# Altium schematic sheet Sumotop_Control_WB_SCH.SchDoc
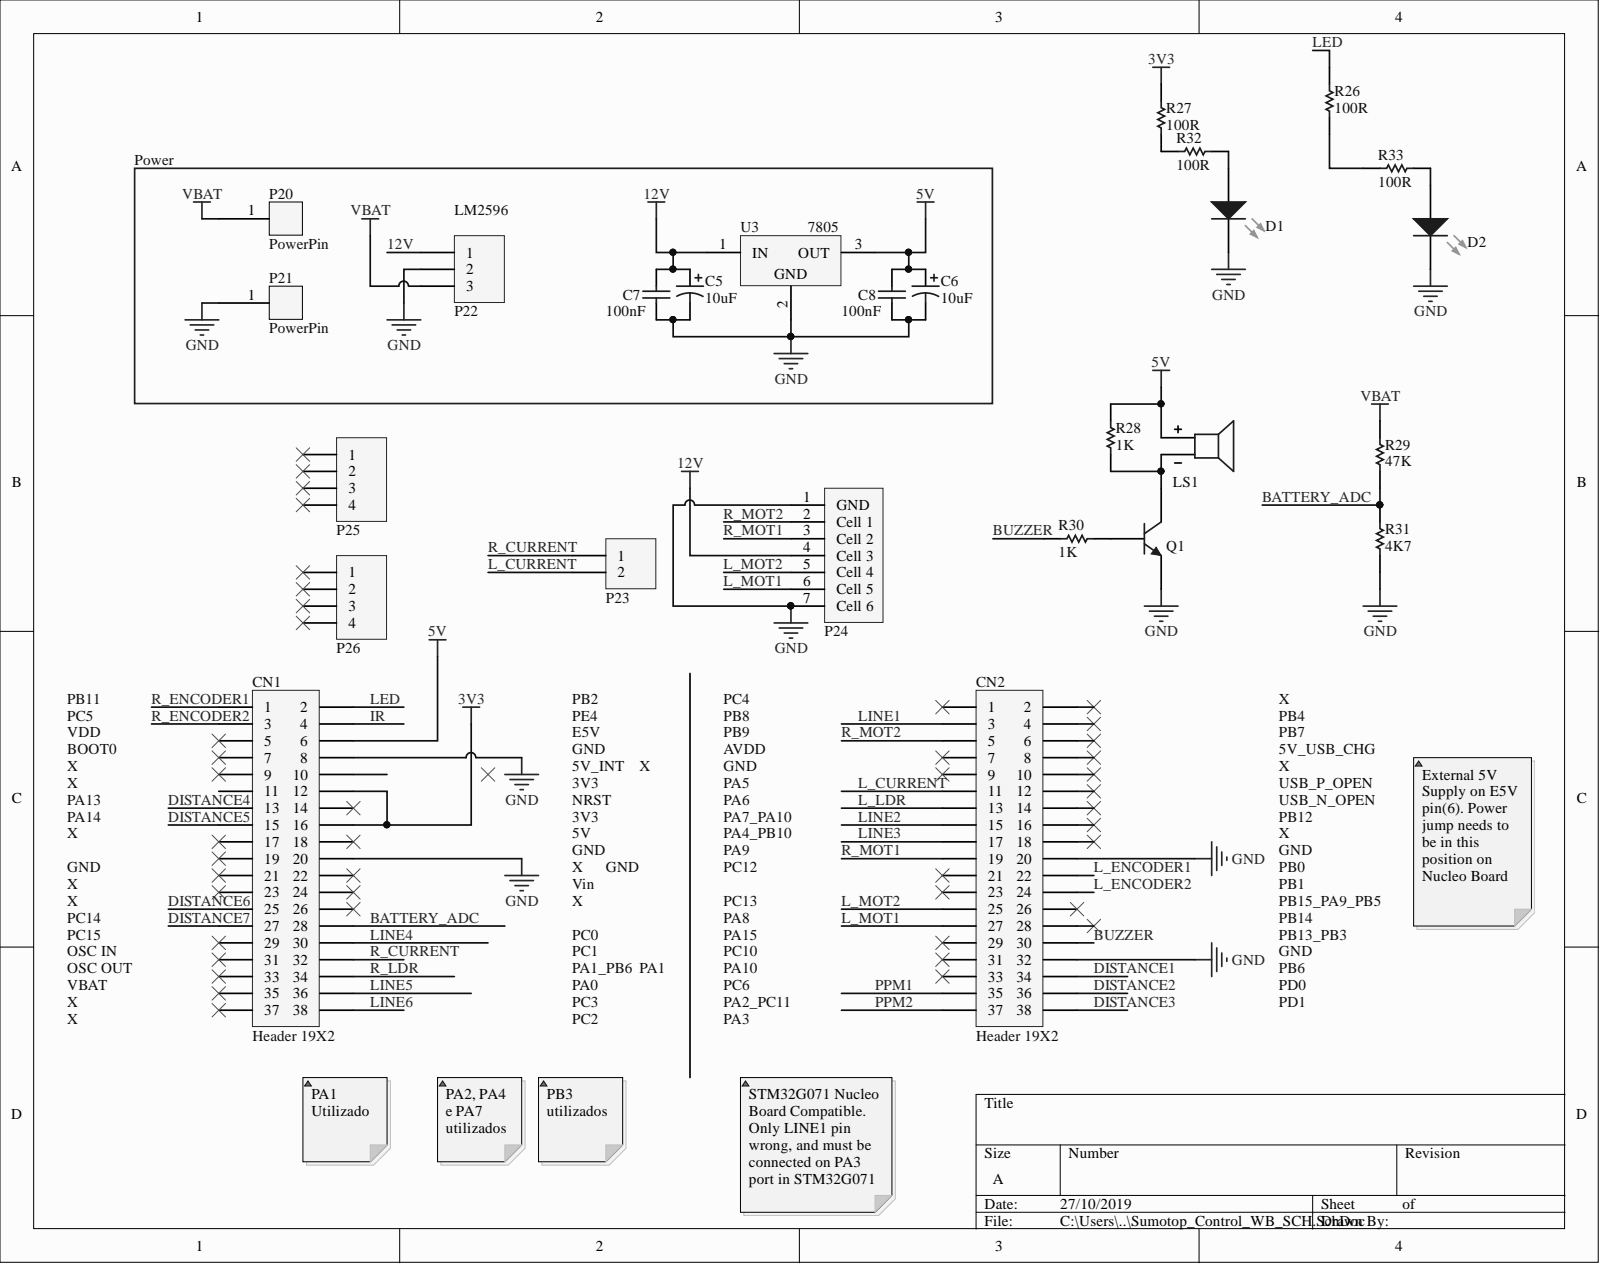
[diagram p0: Sumotop_Control_WB_SCH.SchDoc]

## Parts
C5  10uF  [CAP RAD 10UF 35V]
C6  10uF  [CAP RAD 10UF 35V]
C7  100nF  [Capacitor-0603]
C8  100nF  [Capacitor-0603]
CN1  Header 19X2  [HDR2x19]
CN2  Header 19X2  [HDR2x19]
D1  LED GREEN  [LED1411]  (value not drawn)
D2  LED WHITE  [LED1411]  (value not drawn)
LS1  Buzzer  [BUZZER 12x6MM]  (value not drawn)
P20  PowerPin  [PowerPad]
P21  PowerPin  [PowerPad]
P22  Header 1x3  [HDR1X3]  (value not drawn)
P23  Header 1x2  [HDR1X2]  (value not drawn)
P24  Header Male 1x7  [HDR MALE 1x7]  (value not drawn)
P25  Header 1x4  [HDR MALE 1x4]  (value not drawn)
P26  Header 1x4  [HDR MALE 1x4]  (value not drawn)
Q1  MMBT3904LT1G  [SOT23-3 M]  (value not drawn)
R26  100R  [RESISTOR 0603]
R27  100R  [RESISTOR 0603]
R28  1K  [RESISTOR 0603]
R29  47K  [RESISTOR 0603]
R30  1K  [RESISTOR 0603]
R31  4K7  [RESISTOR 0603]
R32  100R  [RESISTOR 0603]
R33  100R  [RESISTOR 0603]
U3  Name: 7805  [D-PAK]  (hidden comment: LM7805)

## Nets
3V3: CN1.12 CN1.16 R27.2
5V: C6.1 C8.2 CN1.6 LS1.1 R28.2 U3.3
GND: C5.2 C6.2 C7.1 C8.1 CN1.8 CN1.20 CN2.20 CN2.32 D1.2 D2.2 P21.1 P22.2 P24.1(GND) P24.7(Cell_6) Q1.2 R31.1 U3.2
VBAT: P20.1 P22.3 R29.2
12V: C5.1 C7.2 P22.1 P24.4(Cell_3) U3.1
BATTERY_ADC: CN1.28 R29.1 R31.2
BUZZER: CN2.30 R30.1
DISTANCE1: CN2.34
DISTANCE2: CN2.36
DISTANCE3: CN2.38
DISTANCE4: CN1.13
DISTANCE5: CN1.15
DISTANCE6: CN1.25
DISTANCE7: CN1.27
IR: CN1.4
L_CURRENT: CN2.11 P23.2
L_ENCODER1: CN2.22
L_ENCODER2: CN2.24
L_LDR: CN2.13
L_MOT1: CN2.27 P24.6(Cell_5)
L_MOT2: CN2.25 P24.5(Cell_4)
LED: CN1.2 R26.2
LINE1: CN2.3
LINE2: CN2.15
LINE3: CN2.17
LINE4: CN1.30
LINE5: CN1.36
LINE6: CN1.38
PPM1: CN2.35
PPM2: CN2.37
R_CURRENT: CN1.32 P23.1
R_ENCODER1: CN1.1
R_ENCODER2: CN1.3
R_LDR: CN1.34
R_MOT1: CN2.19 P24.3(Cell_2)
R_MOT2: CN2.5 P24.2(Cell_1)
NetD1_1: D1.1 R32.2
NetD2_1: D2.1 R33.2
NetLS1_2: LS1.2 Q1.3 R28.1
NetQ1_1: Q1.1 R30.2
NetR26_1: R26.1 R33.1
NetR27_1: R27.1 R32.1

Not connected: CN1 5 7 9 10 11 14 17 18 19 21 22 23 24 26 29 31 33 35 37; CN2 1 2 4 6 7 8 9 10 12 14 16 18 21 23 26 28 29 31 33; P25 1 2 3 4; P26 1 2 3 4

## Library data of the parts
C5 C6 = CAP 10uF ELE 16V: CAP ELE RAD 10uF 16V; Manufacturer Part Number: IMP1295; Capacitance Tolerance: ± 20%; Capacitor Terminals: Radial Leaded; Leakage Current: 6; Lifetime @ Temperature: 2000 hours @ 85; Product Range: MCGPR Series; SVHC: No SVHC (15-Jun-2015); Voltage Rating: 16; Capacitance: 10uF; Capacitance Tolerance ±: ± 20%; Component Kind: Standard; ComponentLink1Description: http://loja.multcomercial.com.br/capacitor-eletrolitico-10uf-16v.html; ComponentLink1URL: http://loja.multcomercial.com.br/capacitor-eletrolitico-10uf-16v.html; Diameter: 5; ESR: -; Height: 11; Lead Spacing: 2; Manufacturer: -; Operating Temperature Max: 85; Operating Temperature Min: -40; Operating Temperature Range: -40°C to +85°C<!--FANDB--><div class="features-and-benefits-container"><div class="features-and-benefits-description"><span class="features-benefits-value">The Multicomp MCGPR series capacitors are general purpose, radial leaded polarized aluminium electrolytic capacitors. MCGPR series parts cover broad range of voltage ratings and capacitance values.</span></div><div class="features-and-benefits-list"><ul class="features-and-benefits-list-list"><li class="features-and-benefits-list-item">Capacitance value ranges from 0.1µF to 22000µF for 6.3VDC to 100VDC</li><li class="features-and-benefits-list-item">Capacitance value ranges from 0.47µF to 820µF for 160VDC to 450VDC</li><li class="features-and-benefits-list-item">Tolerance of ±20%</li><li class="features-and-benefits-list-item">Endurance of 2000h at 85°C</li><li class="features-and-benefits-list-item">Available in -40°C to 85°C and -25°C to 85; Packaging: Each; Pricing 1: 1=0.50 (BRL); RoHS: YES; Stock 1: 33826; Supplier 1: Mult Comercial; Supplier Part Number 1: Capacitor Eletrolítico 10uF/16V; Library Name: Sumotop_Control.SchLib
C7 C8 = CAP 100NF 50V: Capacitor Cer disk 100nF 50V; Manufacturer Part Number: IMP14925; Capacitance: 0.1uF; Capacitance Tolerance: +80%, -20%; Capacitor Terminals: Radial Leaded; Dielectric Characteristic: Y5; Product Range: MCFY Series; SVHC: No SVHC (15-Jun-2015); Voltage Rating: 50; Capacitance Tolerance ±: +80%, -20%; Component Kind: Standard; ComponentLink1Description: http://loja.multcomercial.com.br/capacitor-ceramico-disco-100kpf-50v-104-100k-100nf-0-1uf-cod-1351.html; ComponentLink1URL: http://loja.multcomercial.com.br/capacitor-ceramico-disco-100kpf-50v-104-100k-100nf-0-1uf-cod-1351.html; Lead Spacing: 5; Manufacturer: -; Operating Temperature Max: 85; Operating Temperature Min: -25; Operating Temperature Range: -25°C to +85°C<!--FANDB--><div class="features-and-benefits-container"><div class="features-and-benefits-description"><span class="features-benefits-value">The MCFY series from Multicomp are radial leaded ceramic disc capacitors. The capacitance values ranges from 6800pF to 0.22?F.</span></div><div class="features-and-benefits-list"><ul class="features-and-benefits-list-list"><li class="features-and-benefits-list-item">Large capacitance in small size</li><li class="features-and-benefits-list-item">Rated voltage of 50V</li><li class="features-and-benefits-list-item">80%/-20% tolerance</li><li class="features-and-benefits-list-item">Operating temperature range -25°C to +85; Packaging: Each; Pricing 1: 1=0.10 (BRL); RoHS: YES; Stock 1: 115809; Supplier 1: Mult Comercial; Supplier Part Number 1: Capacitor Cerâmico Disco 100Kpf/50V (104/100K/100nF/0.1uF) cód. 1351; Library Name: Sumotop_Control_WB_SCHLIB.SchLib
CN1 CN2 = Header 19X2: Header, 19-Pin, Dual row; Component Kind: Standard; Revision: 17-Jul-2002: Re-released for DXP Platform.; Signal Integrity: Connector; Library Name: Sumotop_Control.SchLib; Publisher: Altium Limited
D1 = LED GREEN: Standard LEDs - SMD White TOPLED Black Surface; Manufacturer: Wurth Elektronik; Manufacturer Part Number: 150141GS73113; Luminous Intensity Typ: 2500; Component Kind: Standard; ComponentLink3Description: MOUSER2; ComponentLink3URL: https://br.mouser.com/ProductDetail/Wurth-Elektronik/150141GS73113?qs=sGAEpiMZZMseGfSY3csMkdgyOOAg6kv2zYAUfQmngkdzXM%252Bl%252BPVrNQ%3D%3D; Library Name: Sumotop_Control_WB_SCHLIB.SchLib
D2 = LED WHITE: Standard LEDs - SMD White TOPLED Black Surface; Manufacturer: OSRAM; Manufacturer Part Number: LW TWTG.BB-BYBZ; Luminous Intensity Typ: 2000; Component Kind: Standard; ComponentLink1Description: http://loja.multcomercial.com.br/led-3mm-vermelho-difuso-l-314hd-paralight.html; ComponentLink1URL: http://loja.multcomercial.com.br/led-3mm-vermelho-difuso-l-314hd-paralight.html; ComponentLink2Description: Mouser; ComponentLink2URL: https://br.mouser.com/ProductDetail/OSRAM-Opto-Semiconductors/LW-TWTGBB-BYBZ-2B12C3-Z486?qs=sGAEpiMZZMseGfSY3csMkdgyOOAg6kv20s3Ceu2Hg6%2Fq9qYEXiqoDQ%3D%3D; Library Name: Sumotop_Control_WB_SCHLIB.SchLib
LS1 = Buzzer Piezo transducter 30V th: Manufacturer: TDK Corporation; Manufacturer Part Number: PS1240P02BT; Driver Circuitry: Transducer, Externally Driven; Frequency: 4kHz; Input Type: Zero-Peak Signal; Operating Mode: Single Tone; Port Location: Top; Sound Pressure Level: 60dB @ 3V, 10cm; Voltage - Rated: 3V; Voltage Range: 30V (Max); Approvals: -; Category: Audio Products; Component Kind: Standard; ComponentLink1Description: https://product.tdk.com/info/en/catalog/datasheets/ef532_ps.pdf; ComponentLink1URL: https://product.tdk.com/info/en/catalog/datasheets/ef532_ps.pdf; Current - Supply: -; Description: Buzzer Piezo transducter 30V th; Duration: -; Height - Seated (Max): 0.307" (7.80mm); Mounting Type: Through Hole; Operating Temperature: -10°C ~ 70°C; Packaging: Cut Tape (CT); Part Status: Active; Pricing 1: 1=0.72, 10=0.622, 100=0.3889, 250=0.37776 (USD); Ratings: -; RoHS: RoHS Compliant; Size / Dimension: 0.480" Dia (12.20mm); Stock 1: 23730; Supplier 1: Digi-Key; Supplier Part Number 1: 445-2525-1-ND; Technology: Piezo; Termination: PC Pins; Library Name: Sumotop_Control.SchLib
P22 = Header 1x3: Headers & Wire Housings 40Pin 2mm Spacing Single Row Hdrs, 5pc; Manufacturer Part Number: IMP10327; Brand: Cromax; Mounting Angle: Vertical; Number of Positions: 40 Position; Accessory Type: -; Category: Headers & Wire Housings; Component Kind: Standard; ComponentLink1Description: http://loja.multcomercial.com.br/barra-de-pinos-simples-1x40-macho-bmo40-1e.html; ComponentLink1URL: http://loja.multcomercial.com.br/barra-de-pinos-simples-1x40-macho-bmo40-1e.html; Contact Gender: Pin (Male); Contact Plating: Gold; Contact Type: -; Manufacturer: Cromax; Minimum Order Quantity: 1; Mounting Style: Straight Pin; Number of Rows: 1 Row; Pitch: 2 mm; Pricing 1: 1=1.00, 5=0.20 (BRL); Product: Headers; Product Category: Accessories; Reeling: False; RoHS: RoHS Compliant; RoHS - Mouser: Y; Row Spacing: -; Stock 1: 14; Supplier 1: Mult Comercial; Supplier Part Number 1: Barra de Pinos Simples 1x40 Macho BMO40-1E; Termination Style: Through Hole; Type: Breakaway; Library Name: Sumotop_Control.SchLib
P23 = Header 1x2: Headers & Wire Housings 40Pin 2mm Spacing Single Row Hdrs, 5pc; Manufacturer Part Number: IMP10327; Brand: Cromax; Mounting Angle: Vertical; Number of Positions: 40 Position; Accessory Type: -; Category: Headers & Wire Housings; Component Kind: Standard; ComponentLink1Description: http://loja.multcomercial.com.br/barra-de-pinos-simples-1x40-macho-bmo40-1e.html; ComponentLink1URL: http://loja.multcomercial.com.br/barra-de-pinos-simples-1x40-macho-bmo40-1e.html; Contact Gender: Pin (Male); Contact Plating: Gold; Contact Type: -; Manufacturer: Cromax; Minimum Order Quantity: 1; Mounting Style: Straight Pin; Number of Rows: 1 Row; Pitch: 2 mm; Pricing 1: 1=1.00, 5=0.20 (BRL); Product: Headers; Product Category: Accessories; Reeling: False; RoHS: RoHS Compliant; RoHS - Mouser: Y; Row Spacing: -; Stock 1: 14; Supplier 1: Mult Comercial; Supplier Part Number 1: Barra de Pinos Simples 1x40 Macho BMO40-1E; Termination Style: Through Hole; Type: Breakaway; Library Name: Sumotop_Control.SchLib
P24 = Header Male 1x7: Headers & Wire Housings 40Pin 2mm Spacing Single Row Hdrs, 5pc; Manufacturer Part Number: IMP10327; Brand: Cromax; Mounting Angle: Vertical; Number of Positions: 40 Position; Accessory Type: -; Category: Headers & Wire Housings; Component Kind: Standard; ComponentLink1Description: http://loja.multcomercial.com.br/barra-de-pinos-simples-1x40-macho-bmo40-1e.html; ComponentLink1URL: http://loja.multcomercial.com.br/barra-de-pinos-simples-1x40-macho-bmo40-1e.html; Contact Gender: Pin (Male); Contact Plating: Gold; Contact Type: -; Library Name: Conectores_SCHLIB.SchLib; Manufacturer: Cromax; Minimum Order Quantity: 1; Mounting Style: Straight Pin; Number of Rows: 1 Row; Pitch: 2 mm; Pricing 1: 1=1.00, 5=0.20 (BRL); Product: Headers; Product Category: Accessories; Reeling: False; RoHS: RoHS Compliant; RoHS - Mouser: Y; Row Spacing: -; Stock 1: 14; Supplier 1: Mult Comercial; Supplier Part Number 1: Barra de Pinos Simples 1x40 Macho BMO40-1E; Termination Style: Through Hole; Type: Breakaway
P25 P26 = Header 1x4: Headers & Wire Housings 40Pin 2mm Spacing Single Row Hdrs, 5pc; Manufacturer Part Number: IMP10327; Brand: Cromax; Mounting Angle: Vertical; Number of Positions: 40 Position; Accessory Type: -; Category: Headers & Wire Housings; Component Kind: Standard; ComponentLink1Description: http://loja.multcomercial.com.br/barra-de-pinos-simples-1x40-macho-bmo40-1e.html; ComponentLink1URL: http://loja.multcomercial.com.br/barra-de-pinos-simples-1x40-macho-bmo40-1e.html; Contact Gender: Pin (Male); Contact Plating: Gold; Contact Type: -; Library Name: Conectores_SCHLIB.SchLib; Manufacturer: Cromax; Minimum Order Quantity: 1; Mounting Style: Straight Pin; Number of Rows: 1 Row; Pitch: 2 mm; Pricing 1: 1=1.00, 5=0.20 (BRL); Product: Headers; Product Category: Accessories; Reeling: False; RoHS: RoHS Compliant; RoHS - Mouser: Y; Row Spacing: -; Stock 1: 14; Supplier 1: Mult Comercial; Supplier Part Number 1: Barra de Pinos Simples 1x40 Macho BMO40-1E; Termination Style: Through Hole; Type: Breakaway
Q1 = TRANS NPN 40V 0.2A SOT23: Manufacturer: ON Semiconductor; Current - Collector (Ic) (Max): 200mA; DC Current Gain (hFE) (Min) @ Ic, Vce: 100 @ 10mA, 1V; Frequency - Transition: 300MHz; Power - Max: 300mW; Vce Saturation (Max) @ Ib, Ic: 300mV @ 5mA, 50mA; Category: Discrete Semiconductor Products; Component Kind: Standard; ComponentLink1Description: http://www.onsemi.com/pub_link/Collateral/MMBT3904LT1-D.PDF; ComponentLink1URL: http://www.onsemi.com/pub_link/Collateral/MMBT3904LT1-D.PDF; Current - Collector Cutoff (Max): -; Description: TRANS NPN 40V 0.2A SOT23; Manufacturer Part Number: MMBT3904LT1G; Mounting Type: Surface Mount; Operating Temperature: -55°C ~ 150°C (TJ); Package / Case: TO-236-3, SC-59, SOT-23-3; Packaging: Cut Tape (CT); Part Status: Active; Pricing 1: 1=0.12, 10=0.11, 100=0.0598, 500=0.03678, 1000=0.02508 (USD); RoHS: RoHS Compliant; Stock 1: 1303282; Supplier 1: Digi-Key; Supplier Device Package: SOT-23-3 (TO-236); Supplier Part Number 1: MMBT3904LT1GOSCT-ND; Transistor Type: NPN; Voltage - Collector Emitter Breakdown (Max): 40V; Library Name: Sumotop_Control_WB_SCHLIB.SchLib
R26 R27 R32 R33 = RES 100R 5%: Manufacturer: Ty-Ohm; Manufacturer Part Number: CR25; Power (Watts): 0.25W, 1/4W; Temperature Coefficient: 0/ -500ppm/°C; Tolerance: ±5%; Category: Resistors; Component Kind: Standard; ComponentLink1Description: http://loja.multcomercial.com.br/resistor-de-1-4-de-watt-cr25-5-de-tolerancia.html; ComponentLink1URL: http://loja.multcomercial.com.br/resistor-de-1-4-de-watt-cr25-5-de-tolerancia.html; Composition: Carbon Film; Features: Flame Retardant Coating, Safety; Height: -; Number of Terminations: 2; Operating Temperature: -55°C ~ 155°C; Package / Case: Axial; Packaging: Cut Tape (CT); Pricing 1: 1=0.20 (BRL); Resistance (Ohms): ?; RoHS: RoHS Compliant; Size / Dimension: 0.091" Dia x 0.256" L (2.30mm x 6.50mm); Stock 1: 617543; Supplier 1: Mult Comercial; Supplier Device Package: Axial; Supplier Part Number 1: Resistor de Filme de Carbono 1/4 de Watt CR25 5% de tolerância - 0.22R Ω à 10M Ω; Library Name: Sumotop_Control_WB_SCHLIB.SchLib
R28 R30 = RES 1K 5%: Manufacturer: Ty-Ohm; Manufacturer Part Number: CR25; Power (Watts): 0.25W, 1/4W; Temperature Coefficient: 0/ -500ppm/°C; Tolerance: ±5%; Category: Resistors; Component Kind: Standard; ComponentLink1Description: http://loja.multcomercial.com.br/resistor-de-1-4-de-watt-cr25-5-de-tolerancia.html; ComponentLink1URL: http://loja.multcomercial.com.br/resistor-de-1-4-de-watt-cr25-5-de-tolerancia.html; Composition: Carbon Film; Features: Flame Retardant Coating, Safety; Height: -; Number of Terminations: 2; Operating Temperature: -55°C ~ 155°C; Package / Case: Axial; Packaging: Cut Tape (CT); Pricing 1: 1=0.20 (BRL); Resistance (Ohms): ?; RoHS: RoHS Compliant; Size / Dimension: 0.091" Dia x 0.256" L (2.30mm x 6.50mm); Stock 1: 617543; Supplier 1: Mult Comercial; Supplier Device Package: Axial; Supplier Part Number 1: Resistor de Filme de Carbono 1/4 de Watt CR25 5% de tolerância - 0.22R Ω à 10M Ω; Library Name: Sumotop_Control_WB_SCHLIB.SchLib
R29 = RES 47K 5%: Manufacturer: Ty-Ohm; Manufacturer Part Number: CR25; Power (Watts): 0.25W, 1/4W; Temperature Coefficient: 0/ -500ppm/°C; Tolerance: ±5%; Category: Resistors; Component Kind: Standard; ComponentLink1Description: http://loja.multcomercial.com.br/resistor-de-1-4-de-watt-cr25-5-de-tolerancia.html; ComponentLink1URL: http://loja.multcomercial.com.br/resistor-de-1-4-de-watt-cr25-5-de-tolerancia.html; Composition: Carbon Film; Features: Flame Retardant Coating, Safety; Height: -; Number of Terminations: 2; Operating Temperature: -55°C ~ 155°C; Package / Case: Axial; Packaging: Cut Tape (CT); Pricing 1: 1=0.20 (BRL); RoHS: RoHS Compliant; Size / Dimension: 0.091" Dia x 0.256" L (2.30mm x 6.50mm); Stock 1: 617543; Supplier 1: Mult Comercial; Supplier Device Package: Axial; Supplier Part Number 1: Resistor de Filme de Carbono 1/4 de Watt CR25 5% de tolerância - 0.22R Ω à 10M Ω; Library Name: Sumotop_Control_WB_SCHLIB.SchLib
R31 = RES 4K7 5%: Manufacturer: Ty-Ohm; Manufacturer Part Number: CR25; Power (Watts): 0.25W, 1/4W; Temperature Coefficient: 0/ -500ppm/°C; Tolerance: ±5%; Category: Resistors; Component Kind: Standard; ComponentLink1Description: http://loja.multcomercial.com.br/resistor-de-1-4-de-watt-cr25-5-de-tolerancia.html; ComponentLink1URL: http://loja.multcomercial.com.br/resistor-de-1-4-de-watt-cr25-5-de-tolerancia.html; Composition: Carbon Film; Features: Flame Retardant Coating, Safety; Height: -; Number of Terminations: 2; Operating Temperature: -55°C ~ 155°C; Package / Case: Axial; Packaging: Cut Tape (CT); Pricing 1: 1=0.20 (BRL); RoHS: RoHS Compliant; Size / Dimension: 0.091" Dia x 0.256" L (2.30mm x 6.50mm); Stock 1: 617543; Supplier 1: Mult Comercial; Supplier Device Package: Axial; Supplier Part Number 1: Resistor de Filme de Carbono 1/4 de Watt CR25 5% de tolerância - 0.22R Ω à 10M Ω; Library Name: Sumotop_Control_WB_SCHLIB.SchLib
P20 P21 = PowerPin: Component Kind: Standard; Library Name: Sumotop_Control.SchLib
U3 = LM7805: Component Kind: Standard; Supplier 1: Mouser; Supplier Part Number 1: 926-LM7805CT/NOPB; Library Name: Sumotop_Control_WB_SCHLIB.SchLib
